# Altium schematic sheet MEMS_LIP+Notch.SchDoc(MEMS_LIP+Notch)
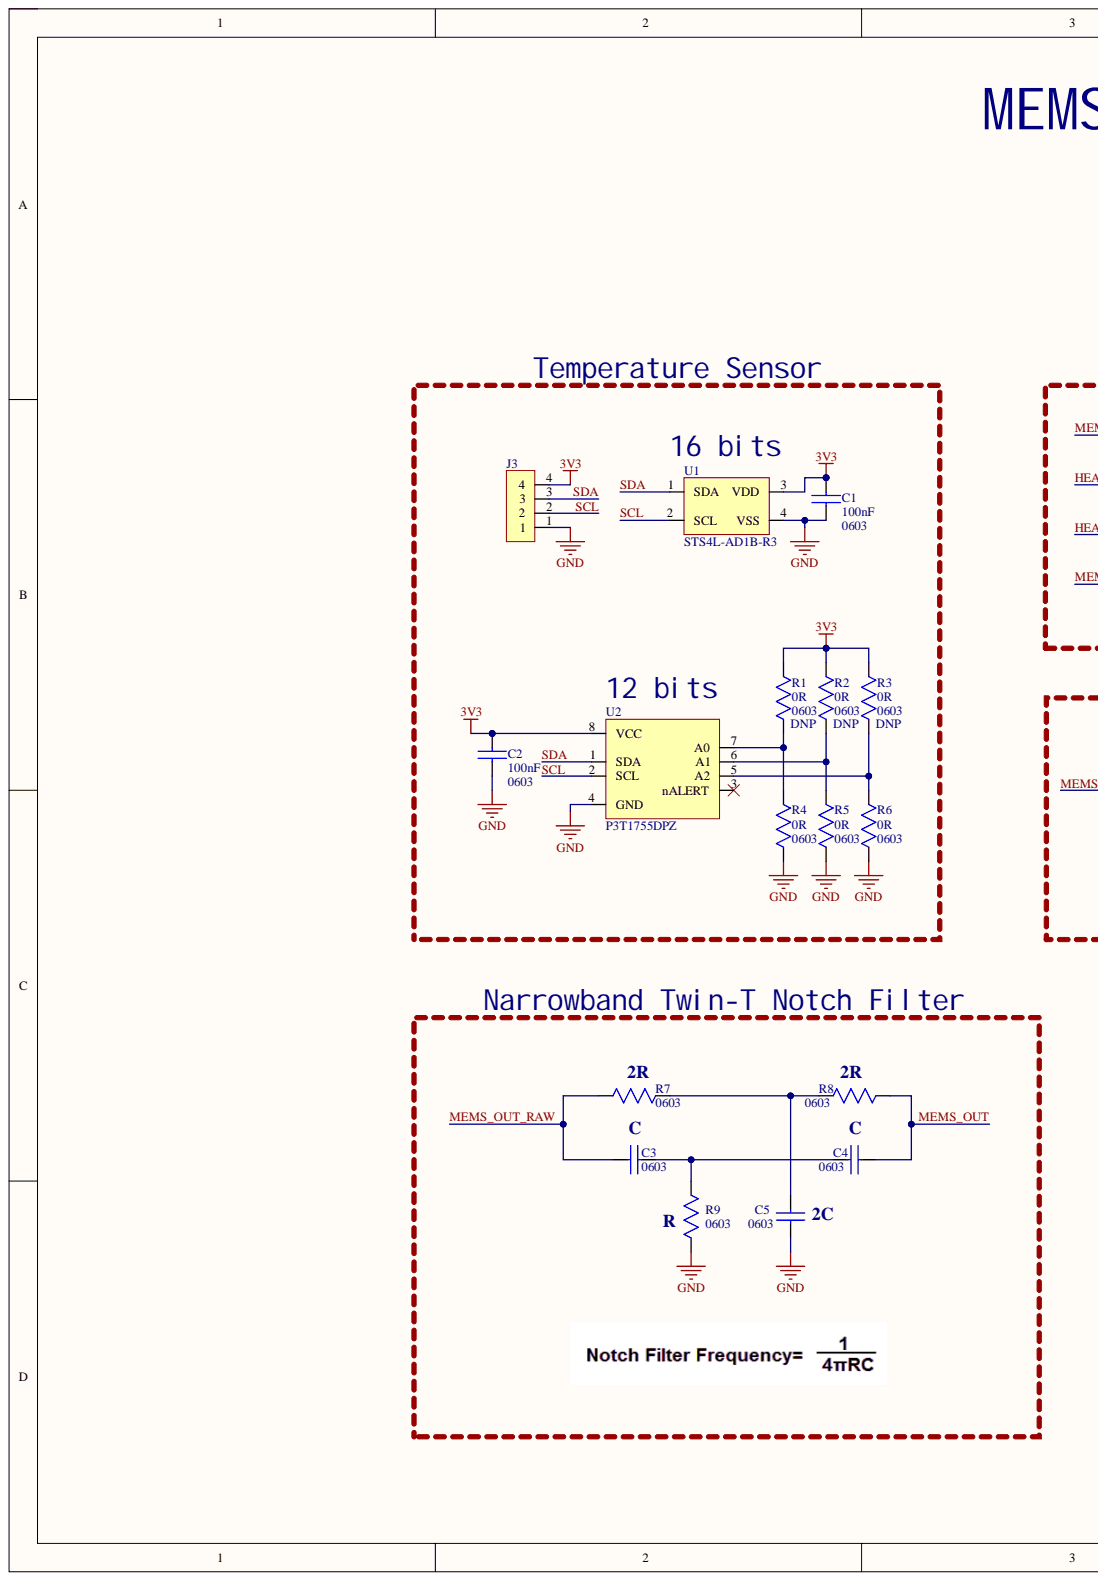
[diagram p0: MEMS_LIP+Notch.SchDoc, left]
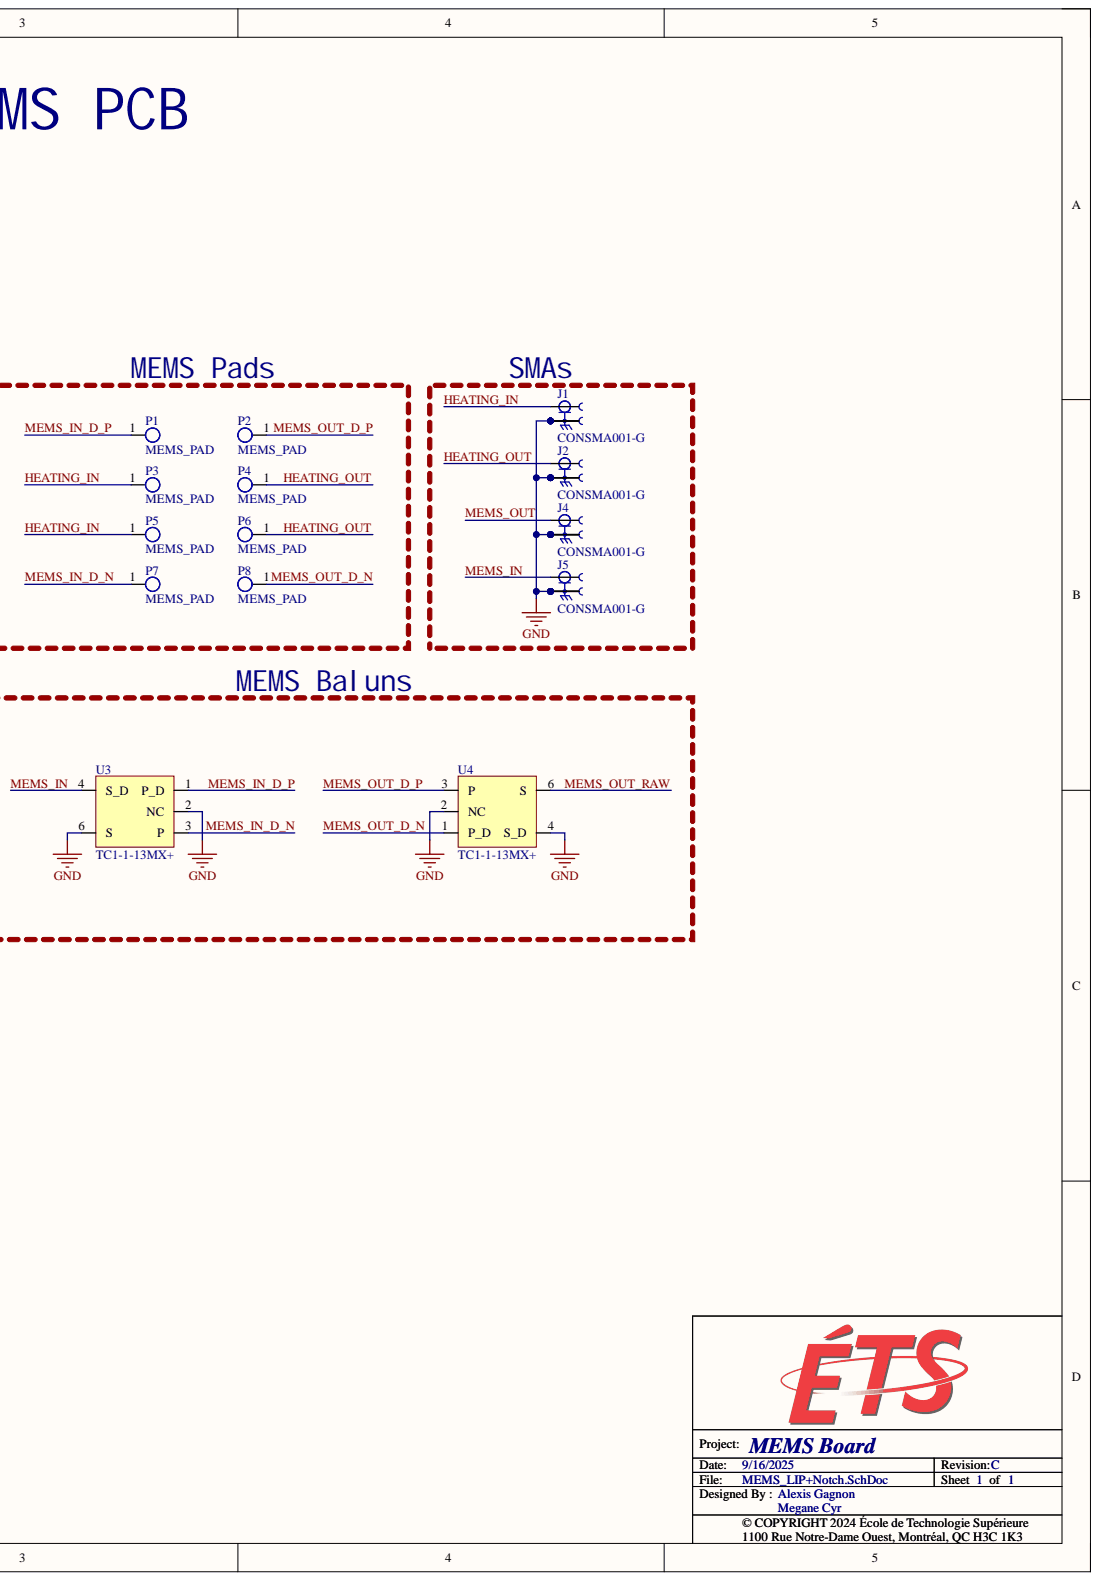
[diagram p1: MEMS_LIP+Notch.SchDoc, right]

## Parts
C1  value 100nF  Case: 0603  [C0603]  (hidden comment: CL10E104KC8VPNC)
C2  value 100nF  Case: 0603  [C0603]  (hidden comment: CL10E104KC8VPNC)
C3  Packaging: 0603  [C0603]  (hidden comment: C0603C270J5GACTU)
C4  Packaging: 0603  [C0603]  (hidden comment: C0603C270J5GACTU)
C5  Packaging: 0603  [C0603]  (hidden comment: C0603C270J5GACTU)
J1  CONSMA001-G  [CONSMA001-G]  (value not drawn)
J2  CONSMA001-G  [CONSMA001-G]  (value not drawn)
J3  61300411121  [WURTH_61300411121]  (value not drawn)
J4  CONSMA001-G  [CONSMA001-G]  (value not drawn)
J5  CONSMA001-G  [CONSMA001-G]  (value not drawn)
P1  MEMS_PAD  [MEMS_PAD]
P2  MEMS_PAD  [MEMS_PAD]
P3  MEMS_PAD  [MEMS_PAD]
P4  MEMS_PAD  [MEMS_PAD]
P5  MEMS_PAD  [MEMS_PAD]
P6  MEMS_PAD  [MEMS_PAD]
P7  MEMS_PAD  [MEMS_PAD]
P8  MEMS_PAD  [MEMS_PAD]
R1  value 0R  Package: 0603  [R0603]  (hidden comment: RMCF0603ZT0R00)
R2  value 0R  Package: 0603  [R0603]  (hidden comment: RMCF0603ZT0R00)
R3  value 0R  Package: 0603  [R0603]  (hidden comment: RMCF0603ZT0R00)
R4  value 0R  Package: 0603  [R0603]  (hidden comment: RMCF0603ZT0R00)
R5  value 0R  Package: 0603  [R0603]  (hidden comment: RMCF0603ZT0R00)
R6  value 0R  Package: 0603  [R0603]  (hidden comment: RMCF0603ZT0R00)
R7  Package: 0603  [R0603]  (hidden comment: RMCF0603ZT0R00)
R8  Package: 0603  [R0603]  (hidden comment: RMCF0603ZT0R00)
R9  Package: 0603  [R0603]  (hidden comment: RMCF0603ZT0R00)
U1  STS4L-AD1B-R3  [STS4L-AD1B-R3]
U2  P3T1755DPZ  [TSSOP8_P3T1755DPZ_NXP]
U3  TC1-1-13MX+  [TC1-1-13MX+]
U4  TC1-1-13MX+  [TC1-1-13MX+]

## Nets
3V3: C1.1 C2.1 J3.4 R1.2 R2.2 R3.2 U1.3(VDD) U2.8(VCC)
GND: C1.2 C2.2 C5.2 J1.S1 J1.S2 J1.S3 J1.S4 J2.S1 J2.S2 J2.S3 J2.S4 J3.1 J4.S1 J4.S2 J4.S3 J4.S4 J5.S1 J5.S2 J5.S3 J5.S4 R4.1 R5.1 R6.1 R9.2 U1.4(VSS) U2.4(GND) U3.2(NC) U3.6(S) U4.2(NC) U4.4(S_D)
HEATING_IN: J1.1 P3.1 P5.1
HEATING_OUT: J2.1 P4.1 P6.1
MEMS_IN: J5.1 U3.4(S_D)
MEMS_IN_D_N: P7.1 U3.3(P)
MEMS_IN_D_P: P1.1 U3.1(P_D)
MEMS_OUT: C4.1 J4.1 R8.1
MEMS_OUT_D_N: P8.1 U4.1(P_D)
MEMS_OUT_D_P: P2.1 U4.3(P)
MEMS_OUT_RAW: C3.1 R7.2 U4.6(S)
SCL: J3.2 U1.2(SCL) U2.2(SCL)
SDA: J3.3 U1.1(SDA) U2.1(SDA)
NetC3_2: C3.2 C4.2 R9.1
NetC5_1: C5.1 R7.1 R8.2
NetR1_1: R1.1 R4.2 U2.7(A0)
NetR2_1: R2.1 R5.2 U2.6(A1)
NetR3_1: R3.1 R6.2 U2.5(A2)

Not connected: U2 3(nALERT)

## Library data of the parts
C1 C2 = CL10E104KC8VPNC: CAP CER 100NF 100V X8L 0603; Manufacturer: Samsung; Component Kind: Standard; Library Name: MEMS_LIB.SchLib; Manufacturer Part Number: CL10E104KC8VPNC; Pricing: 1=0.27, 10=0.155, 50=0.1104, 100=0.0966, 500=0.07306, 1000=0.06573 (USD); RoHS: No; Stock: 47712; Supplier: Digikey; Supplier 1: Mouser; Supplier Part Number: 1276-CL10E104KC8VPNCCT-ND; Supplier Part Number 1: 187-CL10E104KC8VPNC
C3-C5 = C0603C270J5GACTU: CAP CER 27PF 50V C0G/NP0 0603; Component Kind: Standard; Library Name: MEMS_LIB.SchLib; Supplier 1: Newark; Supplier Part Number 1: 53M7200; Value: 27pF
J1 J2 J4 J5 = CONSMA001-G: CONN SMA RCPT STR 50 OHM PCB; Component Kind: Standard; Library Name: MEMS_LIB.SchLib
J3 = 61300411121: CONN HEADER VERT 4POS 2.54MM; MF: WÃ¼rth Elektronik; Check_prices: https://www.snapeda.com/parts/61300411121/W%25C3%25BCrth+Elektronik+Midcom/view-part/?ref=eda; Component Kind: Standard; Library Name: MEMS_LIB.SchLib; MP: 61300411121; Package: None; SnapEDA_Link: https://www.snapeda.com/parts/61300411121/W%25C3%25BCrth+Elektronik+Midcom/view-part/?ref=snap; Supplier 1: Newark; Supplier Part Number 1: 20X0951
P1-P8 = MEMS_PAD: PAD MEMS connection; Component Kind: Standard; Library Name: MEMS_LIB.SchLib
R1-R6 = RMCF0603ZT0R00: RES 0 OHM JUMPER 1/10W 0603; MF: Stackpole Electronics; Check_prices: https://www.snapeda.com/parts/RMCF0603ZT0R00/Stackpole+Electronics+Inc/view-part/?ref=eda; Component Kind: Standard; Library Name: MEMS_LIB.SchLib; MP: RMCF0603ZT0R00; SnapEDA_Link: https://www.snapeda.com/parts/RMCF0603ZT0R00/Stackpole+Electronics+Inc/view-part/?ref=snap; Supplier 1: DigiKey; Supplier Part Number 1: RMCF0603ZT0R00CT-ND
R7-R9 = RMCF0603ZT0R00: RES 0 OHM JUMPER 1/10W 0603; MF: Stackpole Electronics; Value: 0R; Check_prices: https://www.snapeda.com/parts/RMCF0603ZT0R00/Stackpole+Electronics+Inc/view-part/?ref=eda; Component Kind: Standard; Library Name: MEMS_LIB.SchLib; MP: RMCF0603ZT0R00; SnapEDA_Link: https://www.snapeda.com/parts/RMCF0603ZT0R00/Stackpole+Electronics+Inc/view-part/?ref=snap; Supplier 1: DigiKey; Supplier Part Number 1: RMCF0603ZT0R00CT-ND
U1 = STS4L-AD1B-R3: IC - TEMPERATURE SENSOR 4-DFN; Component Kind: Standard; Library Name: MEMS_LIB.SchLib; Supplier 1: DigiKey; Supplier Part Number 1: 1649-STS4L-AD1B-R3CT-ND
U2 = P3T1755DPZ: SENSOR DGTL -40C ~ 125C 8-TSSOP; Component Kind: Standard; Library Name: MEMS_LIB.SchLib; Supplier 1: DigiKey; Supplier Part Number 1: 568-P3T1755DPZCT-ND
U3 U4 = TC1-1-13MX+: 1:1 CORE & WIRE TRANSFORMER, 4.5; Component Kind: Standard; Library Name: MEMS_LIB.SchLib
